# Altium schematic sheet Top_Motor_Driver_Model_D201.SchDoc(Top_Motor_Driver_Model_D201)
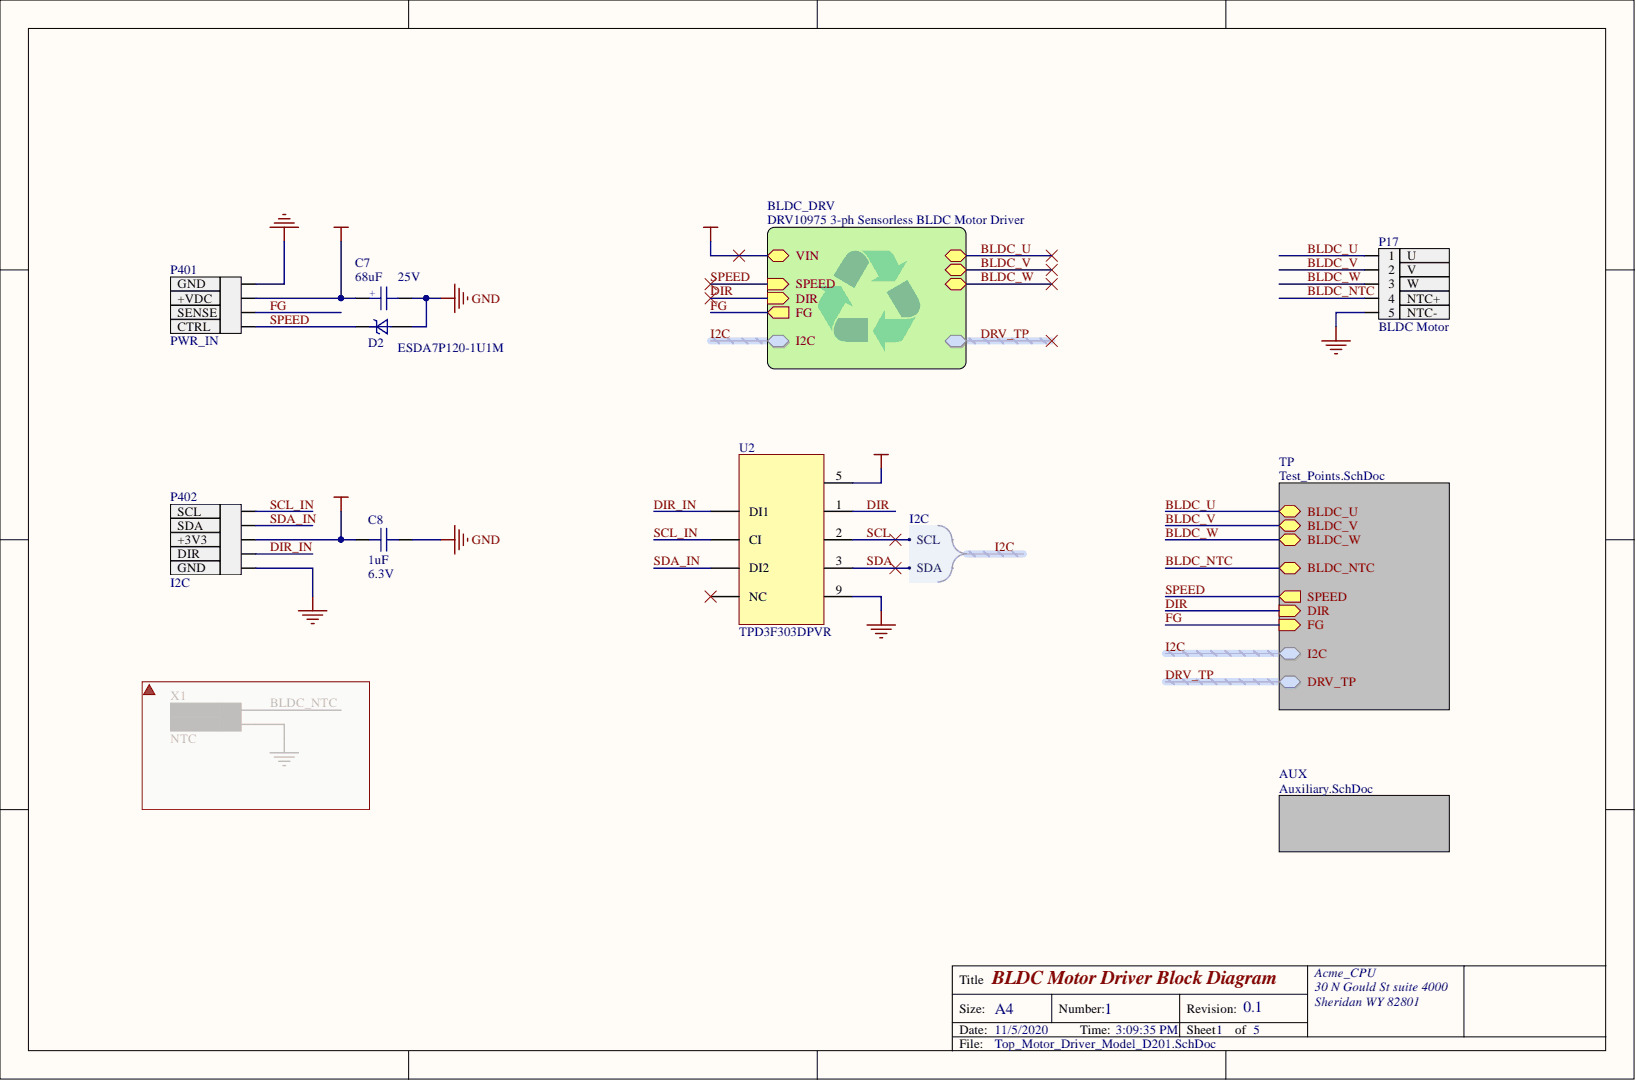
[diagram p0: Top_Motor_Driver_Model_D201.SchDoc]

## Parts
C7  Voltage Rating (DC): 25V  Capacitance: 68uF  [WCAP-ASLI_6.3x5.5]  (hidden comment: CAP ALUM 68 UF 20% 25 V SMD)
C8  Voltage Rating (DC): 6.3V  Capacitance: 1uF  [C0402]  (hidden comment: CAP CER 1UF 6.3V X5R 0402)
D2  ESDA7P120-1U1M  [ST_QFN_1610]
P17  BLDC Motor  pin_5: NTC-  pin_4: NTC+  pin_3: W  pin_2: V  pin_1: U  [CONN_S5B-ZR(LF)(SN)]
P401  PWR_IN  pin_4: CTRL  pin_3: SENSE  pin_2: +VDC  pin_1: GND  [CONN_S4B-ZR(LF)(SN)]
P402  I2C  pin_5: GND  pin_4: DIR  pin_3: +3V3  pin_2: SDA  pin_1: SCL  [CONN_S5B-ZR(LF)(SN)]
U2  TPD3F303DPVR  [DPV8_1P7X0P4]

## Nets
+3V3: C8.2 P402.3 U2.5
GND: C7.2 C8.1 D2.2 P17.5 P401.1 P402.5 U2.9
VSYS: C7.1 P401.2
BLDC_NTC: P17.4
BLDC_U: P17.1
BLDC_V: P17.2
BLDC_W: P17.3
DIR: U2.1
DIR_IN: P402.4 U2.8(DI1)
FG: P401.3(SENSE)
I2C.SCL: U2.2
I2C.SDA: U2.3
SCL_IN: P402.1 U2.7(CI)
SDA_IN: P402.2 U2.6(DI2)
SPEED: D2.1 P401.4
NetU2_4: U2.4(NC)
DRV_TP.SCL:
DRV_TP.SDA:
DRV_TP.V1P8:
DRV_TP.V3P3:
DRV_TP.VREG:

## Library data of the parts
C7 = CAP ALUM 68 UF 20% 25 V SMD: WCAP-ASLI Aluminum Electrolytic Capacitor, V-Chip, SMT, D6.3xH5.5mm, 68uF, 25V; Manufacturer Part Number: 865080443009; Manufacturer: Wurth Elektronik; Technical Reference: ASED055680M025DVCTBB000; Ripple Current (AC): 240mA; Rated Voltage: 25VDC; Polarity: Polar; Life (Hours): 2000Hour; Leakage Current: 17uA; Impedance: 480mR; Endurance: 2000h; Dissipation Factor: 14%; Capacitance Tolerance: +/-20%; Voltage Rating: 25V; Tolerance: 20%; Supplier Part Number 1: 732-8437-1-ND; Supplier 1: Digi-Key; Stock 1: 1129; Ripple Current: 240mA; REACH SVHC: Unknown; Published Date: May 2020; Pricing 1: 1=0.26, 50=0.222, 100=0.194, 250=0.172, 500=0.151 (USD); Packaging: Tape and Reel; Package Quantity: 1000; Operating Temperature: -55 to +105degC; Mounting Technology: SMT; Mount: Surface Mount; Min Operating Temperature: -55°C; Max Operating Temperature: 105°C; Library Name: WCAP-ASLI.SchLib; Height - Seated (Max): 0.217inch; Height: 5.5mm; Diameter: 6.3mm; ComponentLink6URL: http://www.farnell.com/datasheets/1880144.pdf?src-supplier=Element14; ComponentLink6Description: http://www.farnell.com/datasheets/1880144.pdf?src-supplier=Element14; ComponentLink5URL: https://katalog.we-online.de/pbs/datasheet/865080443009.pdf?src-supplier=Digi-Key; ComponentLink5Description: https://katalog.we-online.de/pbs/datasheet/865080443009.pdf?src-supplier=Digi-Key; ComponentLink4URL: http://katalog.we-online.de/pbs/datasheet/865080443009.pdf?src-supplier=Digi-Key; ComponentLink4Description: http://katalog.we-online.de/pbs/datasheet/865080443009.pdf?src-supplier=Digi-Key; ComponentLink3URL: http://www.farnell.com/datasheets/1880144.pdf?src-supplier=Farnell; ComponentLink3Description: http://www.farnell.com/datasheets/1880144.pdf?src-supplier=Farnell; ComponentLink2URL: https://www.we-online.com/catalog/datasheet/865080443009.pdf; ComponentLink2Description: Datasheet; ComponentLink1URL: http://www.we-online.de; ComponentLink1Description: Manufacturer; Component Kind: Standard; Case/Package: Radial
C8 = CAP CER 1UF 6.3V X5R 0402: 1µF ±10% 6.3V Ceramic Capacitor X5R 0402 (1005 Metric); Manufacturer Part Number: CC0402KRX5R5BB105; Manufacturer: Yageo; Width: 0.02inch; Voltage Rating: 6.3V; Tolerance: 10%; Thickness: 0.022inch; Termination: SMD/SMT; Supplier Part Number 1: 311-1438-1-ND; Supplier 1: Digi-Key; Stock 1: 34926286; REACH SVHC: No SVHC; Radiation Hardening: No; Pricing 1: 1=0.1, 10=0.05, 50=0.0272, 100=0.0222, 500=0.0158, 1000=0.0124, 2500=0.0113, 5000=0.0104 (USD); Packaging: Tape and Reel; Package Quantity: 1; Number of Pins: 2; Mount: Surface Mount; Min Operating Temperature: -55°C; Max Operating Temperature: 85°C; Library Name: capacitors_smd.SchLib; Length: 1mm; Lead Free: Lead Free; Height: 0.5mm; Halogen Free: Halogen Free; Dielectric Material: Ceramic; Dielectric: X5R; Depth: 0.5mm; Composition: Ceramic; ComponentLink7URL: http://www.yageo.com/documents/recent/UPY-GPHC_X5R_4V-to-50V_12.pdf?src-supplier=Avnet; ComponentLink7Description: http://www.yageo.com/documents/recent/UPY-GPHC_X5R_4V-to-50V_12.pdf?src-supplier=Avnet; ComponentLink6URL: http://www.farnell.com/datasheets/70765.pdf?src-supplier=Element14; ComponentLink6Description: http://www.farnell.com/datasheets/70765.pdf?src-supplier=Element14; ComponentLink5URL: http://www.farnell.com/datasheets/1359354.pdf?src-supplier=Element14; ComponentLink5Description: http://www.farnell.com/datasheets/1359354.pdf?src-supplier=Element14; ComponentLink4URL: http://www.yageo.com/documents/recent/UPY-GPHC_X5R_4V-to-50V_12.pdf?src-supplier=Avnet+Europe; ComponentLink4Description: http://www.yageo.com/documents/recent/UPY-GPHC_X5R_4V-to-50V_12.pdf?src-supplier=Avnet+Europe; ComponentLink3URL: http://www.farnell.com/datasheets/2167237.pdf?src-supplier=Element14; ComponentLink3Description: http://www.farnell.com/datasheets/2167237.pdf?src-supplier=Element14; ComponentLink2URL: http://www.yageo.com/documents/recent/UPY-GPHC_X5R_4V-to-50V_25.pdf?src-supplier=Digi-Key; ComponentLink2Description: http://www.yageo.com/documents/recent/UPY-GPHC_X5R_4V-to-50V_25.pdf?src-supplier=Digi-Key; ComponentLink1URL: http://www.farnell.com/datasheets/70765.pdf?src-supplier=Farnell; ComponentLink1Description: http://www.farnell.com/datasheets/70765.pdf?src-supplier=Farnell; Component Kind: Standard; Case Code (Metric): 1005; Case Code (Imperial): 0402; Case/Package: 0402
D2 = TVS DIODE ESDA7P120-1U1M 5.5V 11.5V 1610: 11.5V Clamp 120A (8/20µs) Ipp Tvs Diode Surface Mount 1610; Manufacturer: STMicroelectronics; Reverse Standoff Voltage: 5.5V; Power Line Protection: No; Peak Pulse Power: 1.4kW; Number of Unidirectional Channels: 1; Min Breakdown Voltage: 6.4V; Supplier Part Number 1: 497-17159-1-ND; Supplier 1: Digi-Key; Stock 1: 15775; Pricing 1: 1=0.35, 10=0.287, 25=0.2624, 100=0.1647, 250=0.1629, 500=0.1525, 1000=0.1037, 2500=0.0994 (USD); Peak Pulse Current: 120A; Packaging: Cut Tape; Mount: Surface Mount; Min Operating Temperature: -55°C; Max Operating Temperature: 150°C; Manufacturer Part Number: ESDA7P120-1U1M; Library Name: diodes_tvs.SchLib; Composition: Zener; ComponentLink4URL: http://www.farnell.com/datasheets/2815837.pdf?src-supplier=Element14; ComponentLink4Description: http://www.farnell.com/datasheets/2815837.pdf?src-supplier=Element14; ComponentLink3URL: http://www.farnell.com/datasheets/2815837.pdf?src-supplier=Farnell; ComponentLink3Description: http://www.farnell.com/datasheets/2815837.pdf?src-supplier=Farnell; ComponentLink2URL: http://www.farnell.com/datasheets/2815837.pdf?src-supplier=Newark; ComponentLink2Description: http://www.farnell.com/datasheets/2815837.pdf?src-supplier=Newark; ComponentLink1URL: http://www.st.com/content/ccc/resource/technical/document/datasheet/group3/5e/7c/5d/76/3f/de/45/35/DM00359316/files/DM00359316.pdf/jcr:content/translations/en.DM00359316.pdf?src-supplier=Digi-Key; ComponentLink1Description: http://www.st.com/content/ccc/resource/technical/document/datasheet/group3/5e/7c/5d/76/3f/de/45/35/DM00359316/files/DM00359316.pdf/jcr:content/translations/en.DM00359316.pdf?src-supplier=Digi-Key; Component Kind: Standard; Clamping Voltage: 11.5V; Case Code (Metric): 1610; Case Code (Imperial): 0603; Case/Package: 0603
P17 P402 = CONN HEADER TH R/A 5POS 1.5MM: Connector Header Through Hole, Right Angle 5 position 0.059" (1.50mm); Manufacturer Part Number: S5B-ZR(LF)(SN); Manufacturer: JST; Voltage Rating: 50V; Pitch: 1.5mm; Number of Positions: 5; Max Current Rating: 1A; Gender: Male; Contact Resistance: 30mR; Connector Type: Male Pin; Width: 3.5mm; Termination: Press-Fit; Supplier Part Number 1: 455-1672-ND; Supplier 1: Digi-Key; Stock 1: 41138; Pricing 1: 1=0.29, 10=0.272, 100=0.1889, 500=0.1598, 1000=0.1308, 5000=0.1162, 10000=0.1053 (USD); Packaging: Bulk; Orientation: Right Angle; Number of Rows: 1; Number of Pins: 5; Number of Contacts: 5; Mount: Through Hole; Min Operating Temperature: -25°C; Max Voltage Rating (AC): 50V; Max Operating Temperature: 85°C; Library Name: connectors_header.SchLib; Length: 9mm; Lead Free: Lead Free; Housing Material: Polyamide; Housing Color: Beige; Height: 7.2mm; Fastening Type: Detent; Depth: 6mm; Current Rating: 1A; Contact Plating: Tin,Copper; Contact Mating Length: 0.094inch; Contact Material: Brass,Tin; ComponentLink4URL: http://www.produktinfo.conrad.com/datenblaetter/725000-749999/741204-da-01-en-STIFTLEISTE_GEWINKELT_5_POL_RM1_5.pdf?src-supplier=Conrad; ComponentLink4Description: http://www.produktinfo.conrad.com/datenblaetter/725000-749999/741204-da-01-en-STIFTLEISTE_GEWINKELT_5_POL_RM1_5.pdf?src-supplier=Conrad; ComponentLink3URL: http://www1.futureelectronics.com/doc/JST/S5B-ZR(LF)(SN).pdf?src-supplier=Future+Electronics; ComponentLink3Description: http://www1.futureelectronics.com/doc/JST/S5B-ZR(LF)(SN).pdf?src-supplier=Future+Electronics; ComponentLink2URL: https://www.verical.com/server-webapp/html/getDatasheet?partID=640593&src-supplier=Verical; ComponentLink2Description: https://www.verical.com/server-webapp/html/getDatasheet?partID=640593&src-supplier=Verical; ComponentLink1URL: http://www.jst-mfg.com/product/pdf/eng/eZH.pdf?src-supplier=Digi-Key; ComponentLink1Description: http://www.jst-mfg.com/product/pdf/eng/eZH.pdf?src-supplier=Digi-Key; Component Kind: Standard; Color: Natural
P401 = CONN HEADER TH R/A 4POS 1.5MM: Connector Header Through Hole, Right Angle 4 position 0.059" (1.50mm); Manufacturer Part Number: S4B-ZR(LF)(SN); Manufacturer: JST; Voltage Rating (DC): 50V; Pitch: 1.5mm; Panel Thickness: 3.5mm; Number of Positions: 4; Max Current Rating: 1A; Insulation Resistance: 500MR; Gender: Male; Contact Resistance: 30mR; Connector Type: Header,Male Pin; Body Plating: Tin; Width: 3.5mm; Voltage Rating (AC): 50V; Voltage Rating: 50V; Termination: Solder; Supplier Part Number 1: S4B-ZR(LF)(SN); Supplier 1: Arrow; Stock 1: 0; REACH SVHC: No SVHC; Packaging: Bulk; Orientation: Right Angle; Number of Rows: 1; Number of Pins: 4; Number of Contacts: 4; Mount: Through Hole; Min Operating Temperature: -25°C; Max Voltage Rating (DC): 50V; Max Voltage Rating (AC): 50V; Max Operating Temperature: 85°C; Material: Nylon; Library Name: connectors_header.SchLib; Length: 7.5mm; Lead Pitch: 1.5mm; Lead Free: Lead Free; Insulation Material: Nylon; Insulation Color: Natural; Housing Material: Polyamide; Housing Color: Beige; Height: 7.2mm; Flammability Rating: UL94 V-0; Fastening Type: Detent; Depth: 6mm; Current Rating: 1A; Contact Shape: Circular; Contact Plating: Tin,Copper; Contact Mating Length: 0.094inch; Contact Material: Brass,Tin,Copper; ComponentLink6URL: https://www.verical.com/server-webapp/html/getDatasheet?partID=703398&src-supplier=Verical; ComponentLink6Description: https://www.verical.com/server-webapp/html/getDatasheet?partID=703398&src-supplier=Verical; ComponentLink5URL: http://www.farnell.com/datasheets/80556.pdf?src-supplier=Element14; ComponentLink5Description: http://www.farnell.com/datasheets/80556.pdf?src-supplier=Element14; ComponentLink4URL: http://www.jst-mfg.com/product/pdf/eng/eZH.pdf?src-supplier=Digi-Key; ComponentLink4Description: http://www.jst-mfg.com/product/pdf/eng/eZH.pdf?src-supplier=Digi-Key; ComponentLink3URL: http://www1.futureelectronics.com/doc/JST/S4B-ZR(LF)(SN).pdf?src-supplier=Future+Electronics; ComponentLink3Description: http://www1.futureelectronics.com/doc/JST/S4B-ZR(LF)(SN).pdf?src-supplier=Future+Electronics; ComponentLink2URL: https://www.verical.com/server-webapp/html/getDatasheet?partID=640380&src-supplier=Verical; ComponentLink2Description: https://www.verical.com/server-webapp/html/getDatasheet?partID=640380&src-supplier=Verical; ComponentLink1URL: https://www.verical.com/server-webapp/html/getDatasheet?partID=763273&src-supplier=Verical; ComponentLink1Description: https://www.verical.com/server-webapp/html/getDatasheet?partID=763273&src-supplier=Verical; Component Kind: Standard; Color: White
U2 = FILTER RC(PI) ESD SMD  8-UFDFN EP: RC (Pi) EMI Filter 2nd Order Low Pass 3 Channel R = 47Ohms, 100Ohms, C = 20pF  8-UFDFN Exposed Pad; Manufacturer: Texas Instruments; Operating Supply Voltage: 5.5V; Number of Channels: 3; Frequency: 308MHz; ESD Protection: Yes; Clamping Voltage: 10V; Width: 0.063inch; Termination: Flat; Supplier Part Number 1: 296-28299-1-ND; Supplier 1: Digi-Key; Stock 1: 4896; REACH SVHC: No SVHC; Radiation Hardening: No; Pricing 1: 1=0.85, 10=0.812, 25=0.7856, 50=0.6414, 100=0.576, 250=0.5236, 500=0.4974, 1000=0.4451 (USD); Packaging: Tape and Reel; Package Quantity: 1; Number of Pins: 8; Mount: Surface Mount; Min Operating Temperature: -40°C; Max Operating Temperature: 85°C; Manufacturer Part Number: TPD3F303DPVR; Library Name: diodes_tvs.SchLib; Length: 2.2mm; Lead Free: Lead Free; Height: 0.026inch; Depth: 1.7mm; Contact Plating: Gold; ComponentLink4URL: http://www.farnell.com/datasheets/1558442.pdf?src-supplier=Element14; ComponentLink4Description: http://www.farnell.com/datasheets/1558442.pdf?src-supplier=Element14; ComponentLink3URL: https://www.verical.com/server-webapp/html/getDatasheet?partID=302817&src-supplier=Verical; ComponentLink3Description: https://www.verical.com/server-webapp/html/getDatasheet?partID=302817&src-supplier=Verical; ComponentLink2URL: http://focus.ti.com/lit/ds/symlink/tpd3f303.pdf?src-supplier=Avnet+Europe; ComponentLink2Description: http://focus.ti.com/lit/ds/symlink/tpd3f303.pdf?src-supplier=Avnet+Europe; ComponentLink1URL: http://www.ti.com/lit/ds/symlink/tpd3f303.pdf?src-supplier=Digi-Key; ComponentLink1Description: http://www.ti.com/lit/ds/symlink/tpd3f303.pdf?src-supplier=Digi-Key; Component Kind: Standard; Case/Package: SMD/SMT; Capacitance: 20pF
